# Altium schematic sheet EAP_DD_CO_Fixture.SchDoc01.SchDoc(EAP_DD_CO_Fixture.SchDoc01)
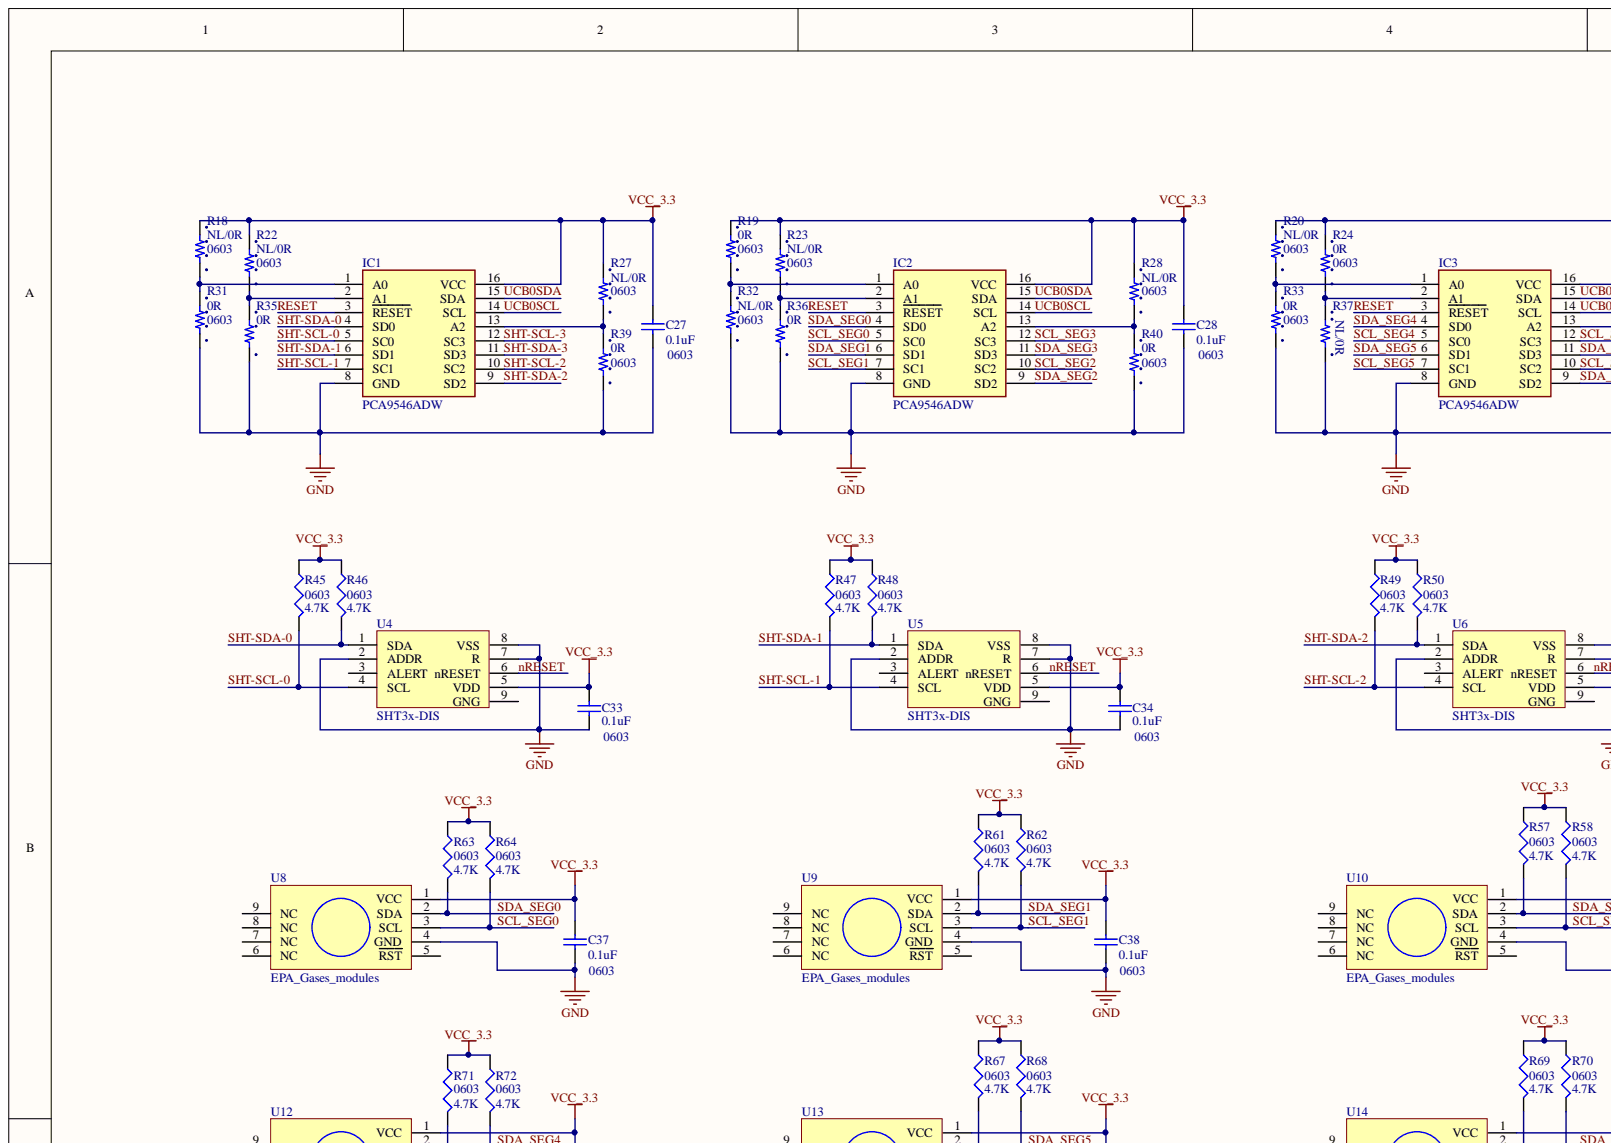
[diagram p0: EAP_DD_CO_Fixture.SchDoc01.SchDoc, top left]
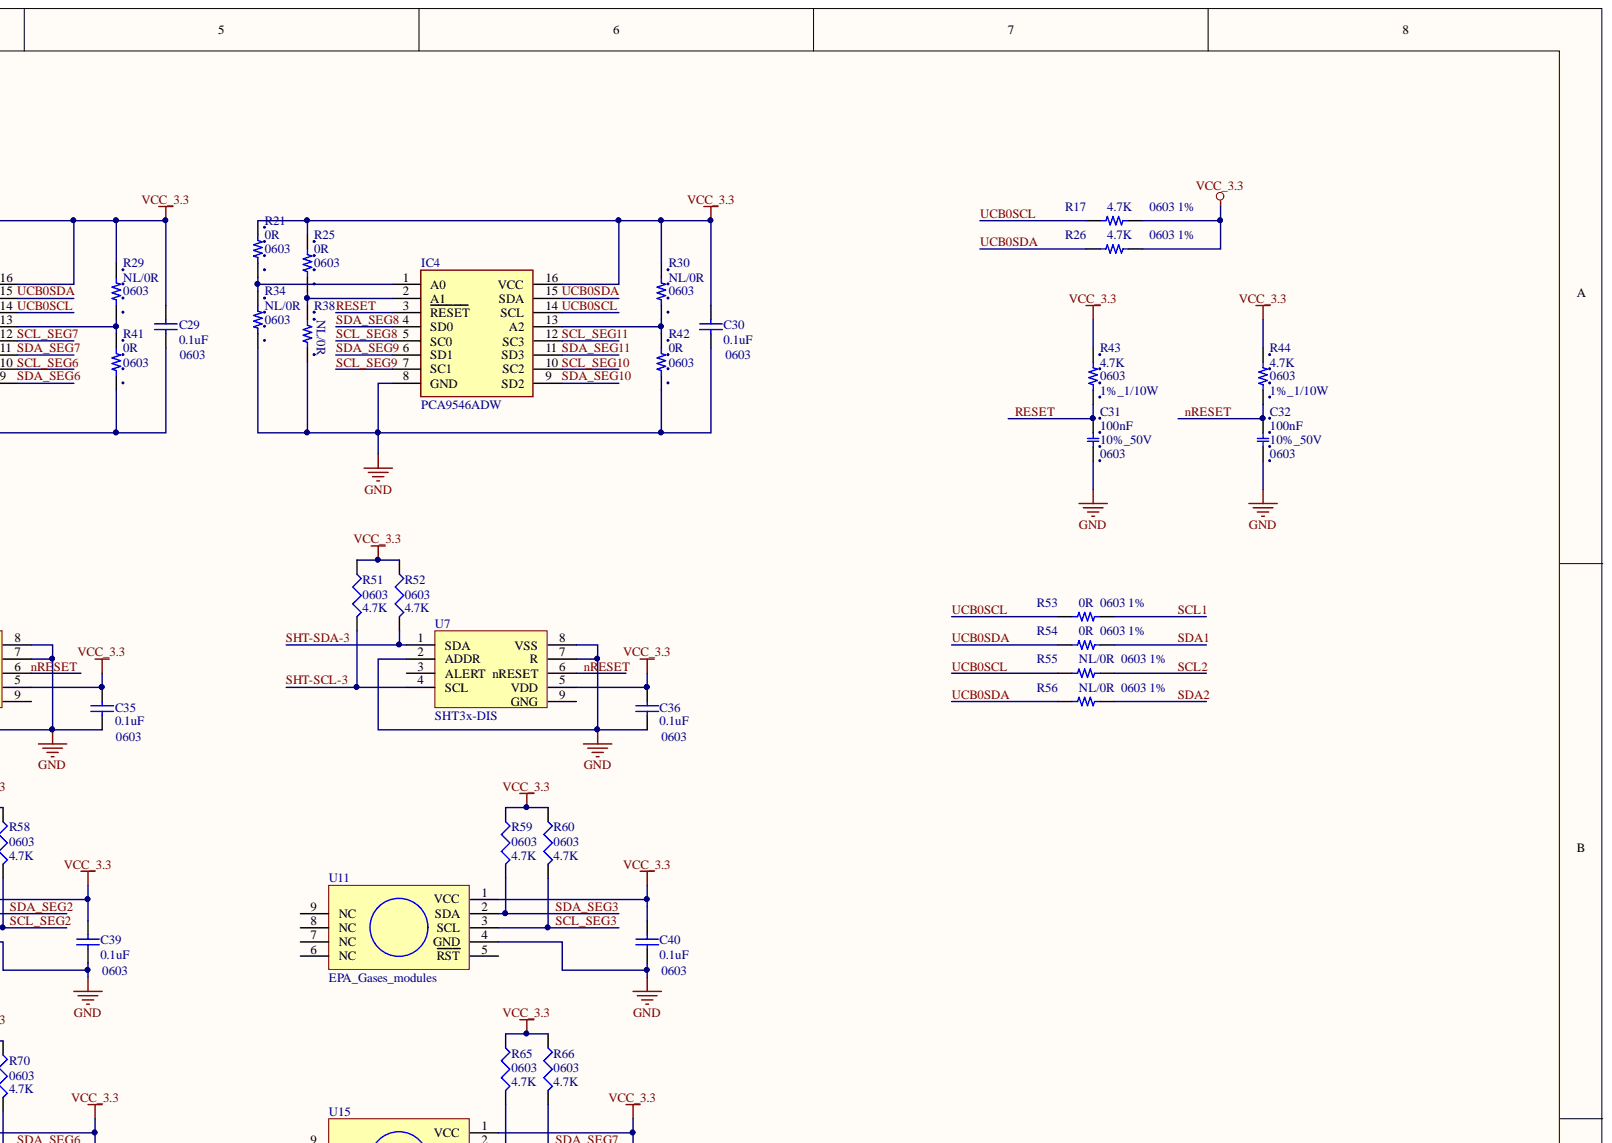
[diagram p1: EAP_DD_CO_Fixture.SchDoc01.SchDoc, top right]
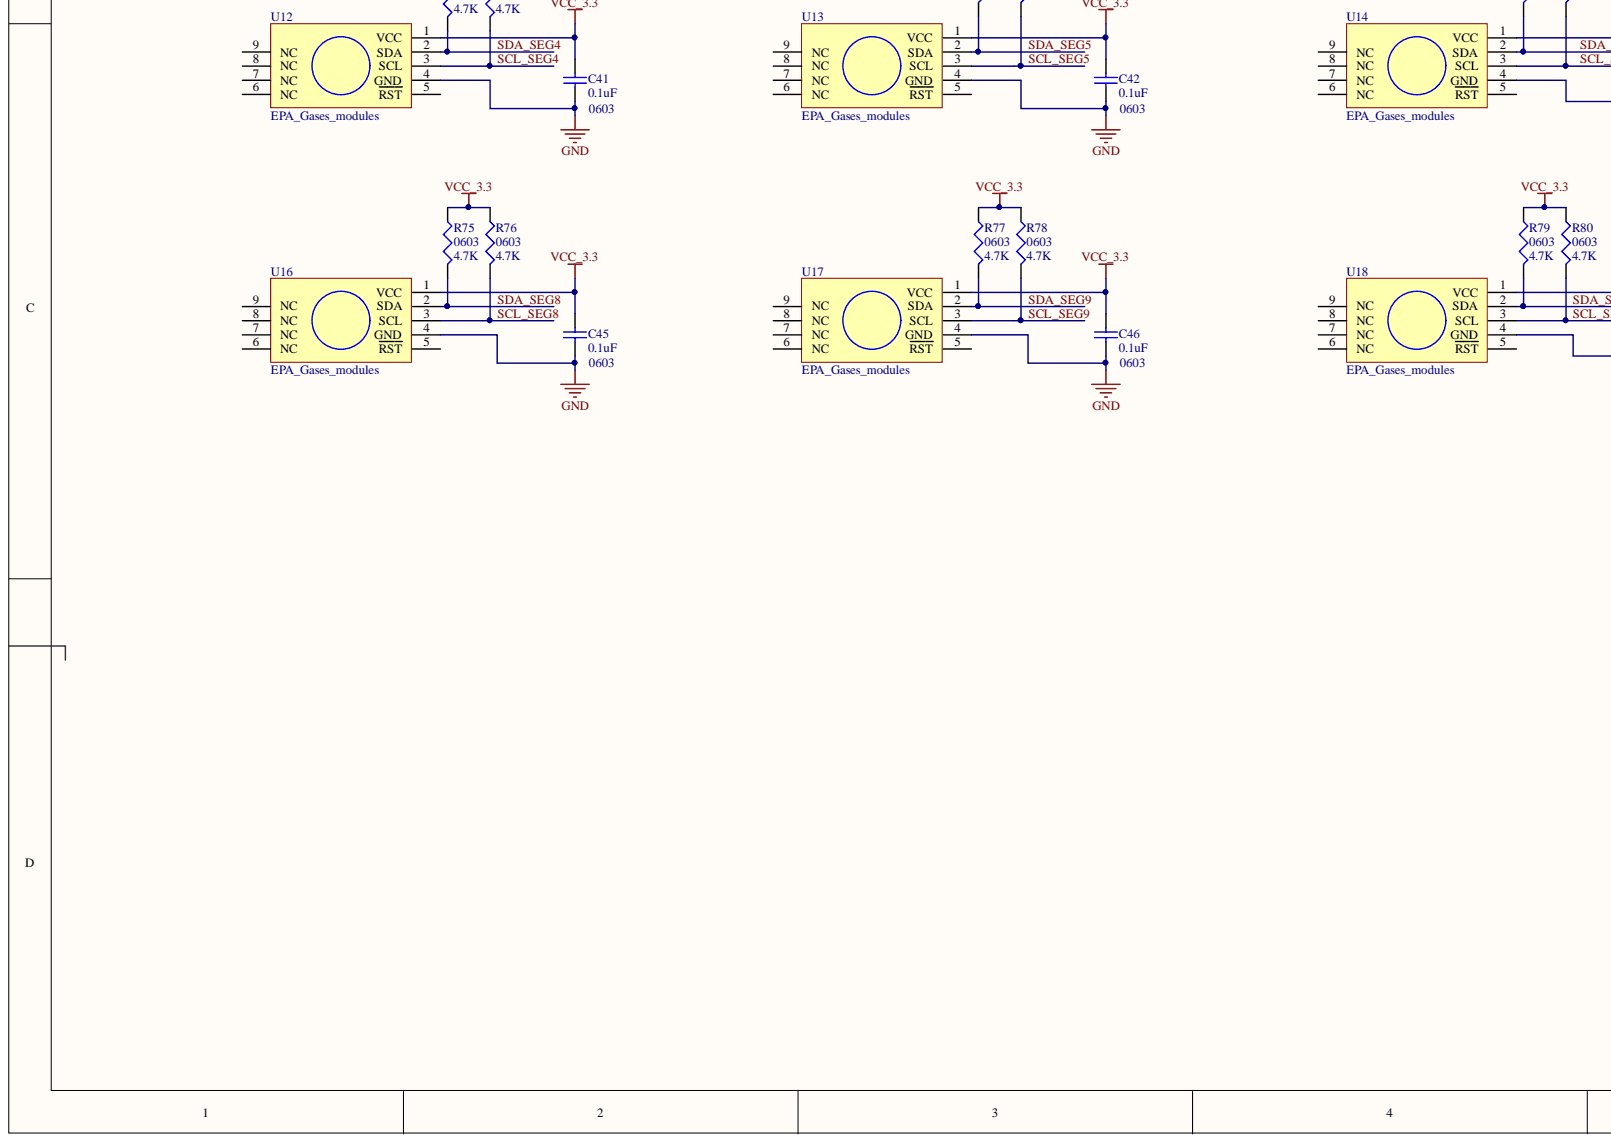
[diagram p2: EAP_DD_CO_Fixture.SchDoc01.SchDoc, bottom left]
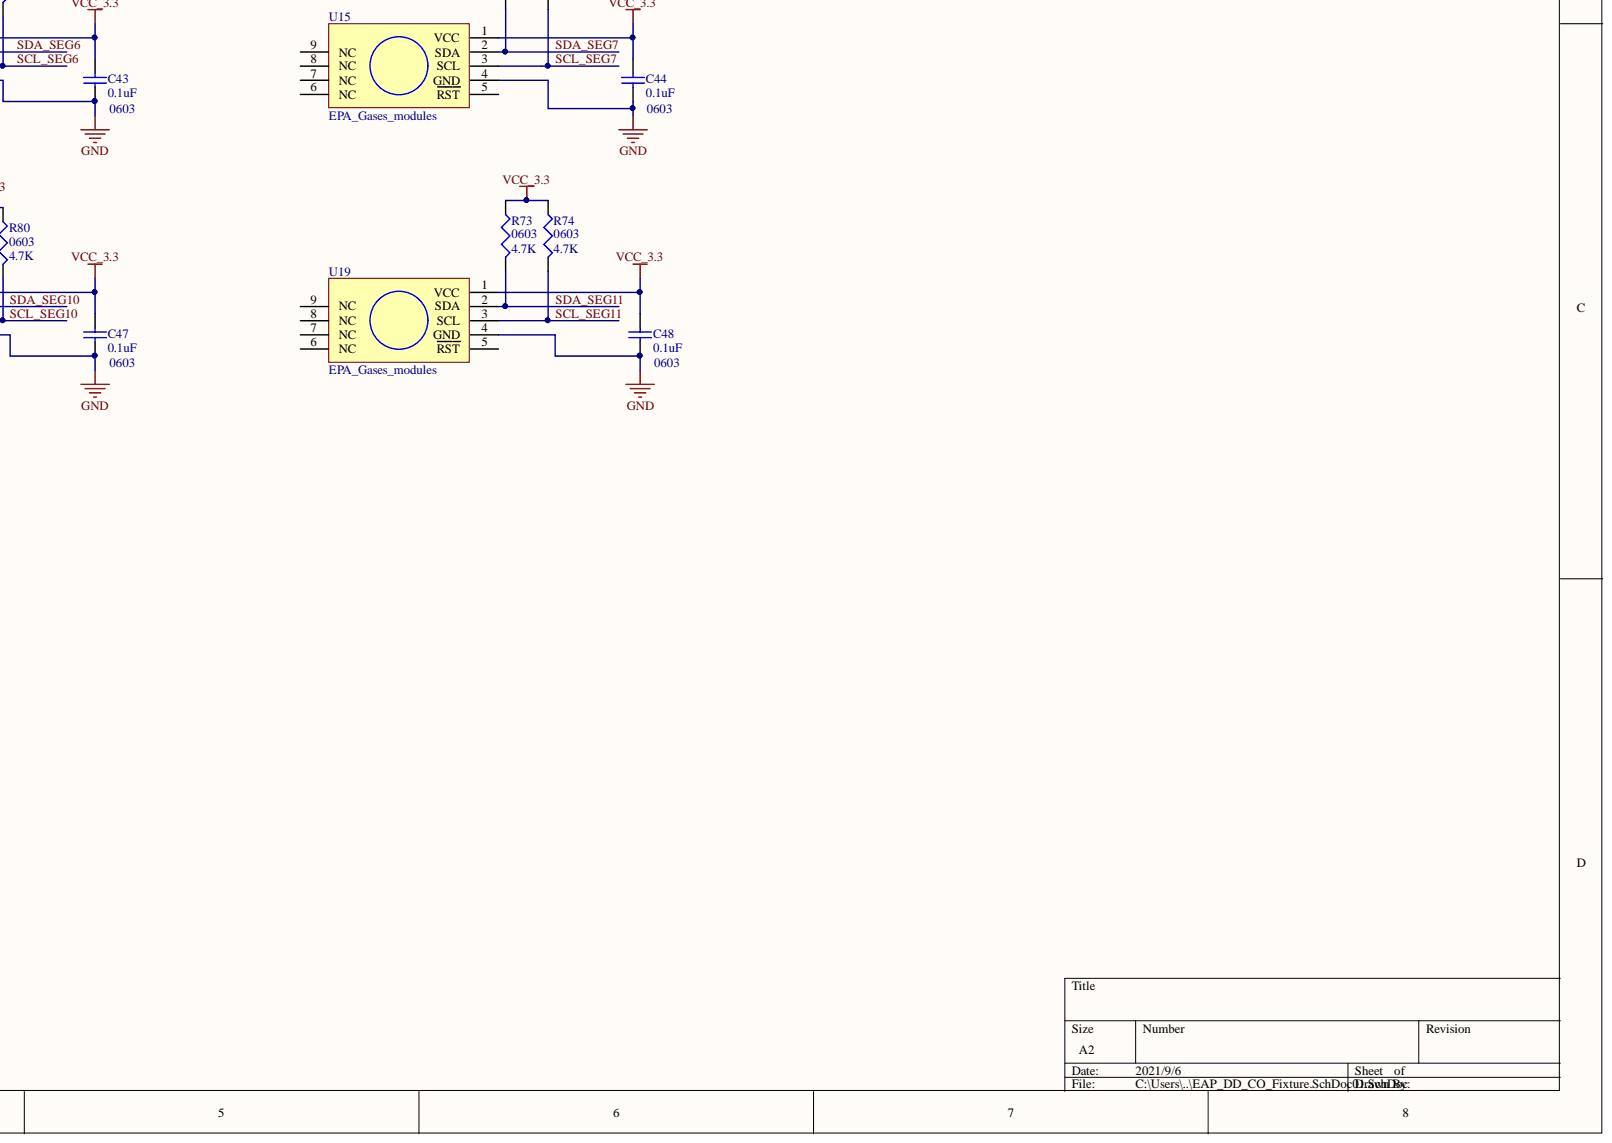
[diagram p3: EAP_DD_CO_Fixture.SchDoc01.SchDoc, bottom right]

## Parts
C27  0603  value 0.1uF  [CC0603]
C28  0603  value 0.1uF  [CC0603]
C29  0603  value 0.1uF  [CC0603]
C30  0603  value 0.1uF  [CC0603]
C31  100nF  Characteristic: 10%_50V  Package: 0603  [CC0603]
C32  100nF  Characteristic: 10%_50V  Package: 0603  [CC0603]
C33  0603  value 0.1uF  [CC0603]
C34  0603  value 0.1uF  [CC0603]
C35  0603  value 0.1uF  [CC0603]
C36  0603  value 0.1uF  [CC0603]
C37  0603  value 0.1uF  [CC0603]
C38  0603  value 0.1uF  [CC0603]
C39  0603  value 0.1uF  [CC0603]
C40  0603  value 0.1uF  [CC0603]
C41  0603  value 0.1uF  [CC0603]
C42  0603  value 0.1uF  [CC0603]
C43  0603  value 0.1uF  [CC0603]
C44  0603  value 0.1uF  [CC0603]
C45  0603  value 0.1uF  [CC0603]
C46  0603  value 0.1uF  [CC0603]
C47  0603  value 0.1uF  [CC0603]
C48  0603  value 0.1uF  [CC0603]
IC1  PCA9546ADW  [SOIC127P1030X265-16N]
IC2  PCA9546ADW  [SOIC127P1030X265-16N]
IC3  PCA9546ADW  [SOIC127P1030X265-16N]
IC4  PCA9546ADW  [SOIC127P1030X265-16N]
R17  4.7K  Characteristic: 1%  Package: 0603  [CR0603]
R18  NL/0R  Package: 0603  [CR0603]
R19  0R  Package: 0603  [CR0603]
R20  NL/0R  Package: 0603  [CR0603]
R21  0R  Package: 0603  [CR0603]
R22  NL/0R  Package: 0603  [CR0603]
R23  NL/0R  Package: 0603  [CR0603]
R24  0R  Package: 0603  [CR0603]
R25  0R  Package: 0603  [CR0603]
R26  4.7K  Characteristic: 1%  Package: 0603  [CR0603]
R27  NL/0R  Package: 0603  [CR0603]
R28  NL/0R  Package: 0603  [CR0603]
R29  NL/0R  Package: 0603  [CR0603]
R30  NL/0R  Package: 0603  [CR0603]
R31  0R  Package: 0603  [CR0603]
R32  NL/0R  Package: 0603  [CR0603]
R33  0R  Package: 0603  [CR0603]
R34  NL/0R  Package: 0603  [CR0603]
R35  0R  [CR0603]
R36  0R  [CR0603]
R37  NL/0R  [CR0603]
R38  NL/0R  [CR0603]
R39  0R  Package: 0603  [CR0603]
R40  0R  Package: 0603  [CR0603]
R41  0R  Package: 0603  [CR0603]
R42  0R  Package: 0603  [CR0603]
R43  4.7K  Characteristic: 1%_1/10W  Package: 0603  [CR0603]
R44  4.7K  Characteristic: 1%_1/10W  Package: 0603  [CR0603]
R45  0603  value 4.7K  [CR0603]
R46  0603  value 4.7K  [CR0603]
R47  0603  value 4.7K  [CR0603]
R48  0603  value 4.7K  [CR0603]
R49  0603  value 4.7K  [CR0603]
R50  0603  value 4.7K  [CR0603]
R51  0603  value 4.7K  [CR0603]
R52  0603  value 4.7K  [CR0603]
R53  0R  Characteristic: 1%  Package: 0603  [CR0603]
R54  0R  Characteristic: 1%  Package: 0603  [CR0603]
R55  NL/0R  Characteristic: 1%  Package: 0603  [CR0603]
R56  NL/0R  Characteristic: 1%  Package: 0603  [CR0603]
R57  0603  value 4.7K  [CR0603]
R58  0603  value 4.7K  [CR0603]
R59  0603  value 4.7K  [CR0603]
R60  0603  value 4.7K  [CR0603]
R61  0603  value 4.7K  [CR0603]
R62  0603  value 4.7K  [CR0603]
R63  0603  value 4.7K  [CR0603]
R64  0603  value 4.7K  [CR0603]
R65  0603  value 4.7K  [CR0603]
R66  0603  value 4.7K  [CR0603]
R67  0603  value 4.7K  [CR0603]
R68  0603  value 4.7K  [CR0603]
R69  0603  value 4.7K  [CR0603]
R70  0603  value 4.7K  [CR0603]
R71  0603  value 4.7K  [CR0603]
R72  0603  value 4.7K  [CR0603]
R73  0603  value 4.7K  [CR0603]
R74  0603  value 4.7K  [CR0603]
R75  0603  value 4.7K  [CR0603]
R76  0603  value 4.7K  [CR0603]
R77  0603  value 4.7K  [CR0603]
R78  0603  value 4.7K  [CR0603]
R79  0603  value 4.7K  [CR0603]
R80  0603  value 4.7K  [CR0603]
U4  SHT3x-DIS  [DFN-8-0.5MM]
U5  SHT3x-DIS  [DFN-8-0.5MM]
U6  SHT3x-DIS  [DFN-8-0.5MM]
U7  SHT3x-DIS  [DFN-8-0.5MM]
U8  EPA_Gases_modules  [AECL_EC_4P_V01]
U9  EPA_Gases_modules  [AECL_EC_4P_V01]
U10  EPA_Gases_modules  [AECL_EC_4P_V01]
U11  EPA_Gases_modules  [AECL_EC_4P_V01]
U12  EPA_Gases_modules  [AECL_EC_4P_V01]
U13  EPA_Gases_modules  [AECL_EC_4P_V01]
U14  EPA_Gases_modules  [AECL_EC_4P_V01]
U15  EPA_Gases_modules  [AECL_EC_4P_V01]
U16  EPA_Gases_modules  [AECL_EC_4P_V01]
U17  EPA_Gases_modules  [AECL_EC_4P_V01]
U18  EPA_Gases_modules  [AECL_EC_4P_V01]
U19  EPA_Gases_modules  [AECL_EC_4P_V01]

## Nets
GND: C27.2 C28.2 C29.2 C30.2 C31.2 C32.2 C33.2 C34.2 C35.2 C36.2 C37.2 C38.2 C39.2 C40.2 C41.2 C42.2 C43.2 C44.2 C45.2 C46.2 C47.2 C48.2 IC1.8(GND) IC2.8(GND) IC3.8(GND) IC4.8(GND) R31.2 R32.2 R33.2 R34.2 R35.2 R36.2 R37.2 R38.2 R39.2 R40.2 R41.2 R42.2 U4.2(ADDR) U4.7(R) U4.8(VSS) U5.2(ADDR) U5.7(R) U5.8(VSS) U6.2(ADDR) U6.7(R) U6.8(VSS) U7.2(ADDR) U7.7(R) U7.8(VSS) U8.4(GND) U9.4(GND) U10.4(GND) U11.4(GND) U12.4(GND) U13.4(GND) U14.4(GND) U15.4(GND) U16.4(GND) U17.4(GND) U18.4(GND) U19.4(GND)
VCC_3.3: C27.1 C28.1 C29.1 C30.1 C33.1 C34.1 C35.1 C36.1 C37.1 C38.1 C39.1 C40.1 C41.1 C42.1 C43.1 C44.1 C45.1 C46.1 C47.1 C48.1 IC1.16(VCC) IC2.16(VCC) IC3.16(VCC) IC4.16(VCC) R17.2 R18.1 R19.1 R20.1 R21.1 R22.1 R23.1 R24.1 R25.1 R26.2 R27.1 R28.1 R29.1 R30.1 R43.1 R44.1 R45.1 R46.1 R47.1 R48.1 R49.1 R50.1 R51.1 R52.1 R57.1 R58.1 R59.1 R60.1 R61.1 R62.1 R63.1 R64.1 R65.1 R66.1 R67.1 R68.1 R69.1 R70.1 R71.1 R72.1 R73.1 R74.1 R75.1 R76.1 R77.1 R78.1 R79.1 R80.1 U4.5(VDD) U5.5(VDD) U6.5(VDD) U7.5(VDD) U8.1(VCC) U9.1(VCC) U10.1(VCC) U11.1(VCC) U12.1(VCC) U13.1(VCC) U14.1(VCC) U15.1(VCC) U16.1(VCC) U17.1(VCC) U18.1(VCC) U19.1(VCC)
NRESET: C32.1 R44.2 U4.6(nRESET) U5.6(nRESET) U6.6(nRESET) U7.6(nRESET)
RESET: C31.1 IC1.3(RESET) IC2.3(RESET) IC3.3(RESET) IC4.3(RESET) R43.2
SCL1: R53.2
SCL2: R55.2
SCL_SEG0: IC2.5(SC0) R64.2 U8.3(SCL)
SCL_SEG1: IC2.7(SC1) R62.2 U9.3(SCL)
SCL_SEG2: IC2.10(SC2) R58.2 U10.3(SCL)
SCL_SEG3: IC2.12(SC3) R60.2 U11.3(SCL)
SCL_SEG4: IC3.5(SC0) R72.2 U12.3(SCL)
SCL_SEG5: IC3.7(SC1) R68.2 U13.3(SCL)
SCL_SEG6: IC3.10(SC2) R70.2 U14.3(SCL)
SCL_SEG7: IC3.12(SC3) R66.2 U15.3(SCL)
SCL_SEG8: IC4.5(SC0) R76.2 U16.3(SCL)
SCL_SEG9: IC4.7(SC1) R78.2 U17.3(SCL)
SCL_SEG10: IC4.10(SC2) R80.2 U18.3(SCL)
SCL_SEG11: IC4.12(SC3) R74.2 U19.3(SCL)
SDA1: R54.2
SDA2: R56.2
SDA_SEG0: IC2.4(SD0) R63.2 U8.2(SDA)
SDA_SEG1: IC2.6(SD1) R61.2 U9.2(SDA)
SDA_SEG2: IC2.9(SD2) R57.2 U10.2(SDA)
SDA_SEG3: IC2.11(SD3) R59.2 U11.2(SDA)
SDA_SEG4: IC3.4(SD0) R71.2 U12.2(SDA)
SDA_SEG5: IC3.6(SD1) R67.2 U13.2(SDA)
SDA_SEG6: IC3.9(SD2) R69.2 U14.2(SDA)
SDA_SEG7: IC3.11(SD3) R65.2 U15.2(SDA)
SDA_SEG8: IC4.4(SD0) R75.2 U16.2(SDA)
SDA_SEG9: IC4.6(SD1) R77.2 U17.2(SDA)
SDA_SEG10: IC4.9(SD2) R79.2 U18.2(SDA)
SDA_SEG11: IC4.11(SD3) R73.2 U19.2(SDA)
SHT-SCL-0: IC1.5(SC0) R45.2 U4.4(SCL)
SHT-SCL-1: IC1.7(SC1) R47.2 U5.4(SCL)
SHT-SCL-2: IC1.10(SC2) R49.2 U6.4(SCL)
SHT-SCL-3: IC1.12(SC3) R51.2 U7.4(SCL)
SHT-SDA-0: IC1.4(SD0) R46.2 U4.1(SDA)
SHT-SDA-1: IC1.6(SD1) R48.2 U5.1(SDA)
SHT-SDA-2: IC1.9(SD2) R50.2 U6.1(SDA)
SHT-SDA-3: IC1.11(SD3) R52.2 U7.1(SDA)
UCB0SCL: IC1.14(SCL) IC2.14(SCL) IC3.14(SCL) IC4.14(SCL) R17.1 R53.1 R55.1
UCB0SDA: IC1.15(SDA) IC2.15(SDA) IC3.15(SDA) IC4.15(SDA) R26.1 R54.1 R56.1
NetIC1_1: IC1.1(A0) R18.2 R31.1
NetIC1_2: IC1.2(A1) R22.2 R35.1
NetIC1_13: IC1.13(A2) R27.2 R39.1
NetIC2_1: IC2.1(A0) R19.2 R32.1
NetIC2_2: IC2.2(A1) R23.2 R36.1
NetIC2_13: IC2.13(A2) R28.2 R40.1
NetIC3_1: IC3.1(A0) R20.2 R33.1
NetIC3_2: IC3.2(A1) R24.2 R37.1
NetIC3_13: IC3.13(A2) R29.2 R41.1
NetIC4_1: IC4.1(A0) R21.2 R34.1
NetIC4_2: IC4.2(A1) R25.2 R38.1
NetIC4_13: IC4.13(A2) R30.2 R42.1
N00130:

Not connected: U4 3(ALERT) 9(GNG); U5 3(ALERT) 9(GNG); U6 3(ALERT) 9(GNG); U7 3(ALERT) 9(GNG); U8 5(RST) 6(NC) 7(NC) 8(NC) 9(NC); U9 5(RST) 6(NC) 7(NC) 8(NC) 9(NC); U10 5(RST) 6(NC) 7(NC) 8(NC) 9(NC); U11 5(RST) 6(NC) 7(NC) 8(NC) 9(NC); U12 5(RST) 6(NC) 7(NC) 8(NC) 9(NC); U13 5(RST) 6(NC) 7(NC) 8(NC) 9(NC); U14 5(RST) 6(NC) 7(NC) 8(NC) 9(NC); U15 5(RST) 6(NC) 7(NC) 8(NC) 9(NC); U16 5(RST) 6(NC) 7(NC) 8(NC) 9(NC); U17 5(RST) 6(NC) 7(NC) 8(NC) 9(NC); U18 5(RST) 6(NC) 7(NC) 8(NC) 9(NC); U19 5(RST) 6(NC) 7(NC) 8(NC) 9(NC)

## Library data of the parts
C27-C30 C33-C48 = Cap Semi: Capacitor (Semiconductor SIM Model); Component Kind: Standard; Published: 8-Jun-2000; Revision: July-2002: Re-released for DXP Platform.; Signal Integrity: Cap Semi; Simulation: CAP; Library Name: AECL_FAE.SCHLIB; Publisher: Altium Limited
C31 C32 = ChipCap: MLCC; AVL_Part: AVL; Manufacture: 華新科技股份有限公司(Walsin Technology Corporation)||台灣東電化股份有限公司(TDK Taiwan Corporation)||台灣太陽誘電(股)公司(Taiwan  Taiyo Yuden  Co., Ltd.); Manufacture_Part_Number: 0603X105K250CT||C1608X5R1E105KT||TMK107BJ105KA; Part_Number: 1100007912; CLASS: DISCRETE; ProcessType: SMT; Tolerance: 10%; Component Kind: Standard; Library Name: ES_passive.SCHLIB
IC1-IC4 = PCA9546ADW: Integrated Circuit; Manufacturer_Name: Texas Instruments; Arrow Part Number: PCA9546ADW; Arrow Price/Stock: https://www.arrow.com/en/products/pca9546adw/texas-instruments; Component Kind: Standard; Datasheet Link: http://www.ti.com/lit/gpn/PCA9546A; Height: 2.65mm; Manufacturer_Part_Number: PCA9546ADW; Mouser Part Number: 595-PCA9546ADW; Mouser Price/Stock: https://www.mouser.co.uk/ProductDetail/Texas-Instruments/PCA9546ADW?qs=wgAEGBTxy7kByJKC9EZsVQ%3D%3D; Library Name: EAP_DD_CO_Fixture.SCHLIB
R17 R26 R53-R56 = ChipRes: Chip Resistor; AVL_Part: AVL; Manufacture: 厚聲電子工業有限公司(Uniroyal Electronics Industry Co.,Ltd.)||國巨股份有限公司(Yageo Co.,Ltd.)||大毅科技股份有限公司(Ta-I Technolongy Co., Ltd.); Manufacture_Part_Number: 0603WAF2200T5E||RC0603FR-07220RL||RM06FTN2200; Part_Number: 105A522003; CLASS: DISCRETE; ProcessType: SMT; Component Kind: Standard; Library Name: ES_passive.SCHLIB; Tolerance: 1%
R18-R25 R27-R44 = ChipRes: Chip Resistor; AVL_Part: AVL; Manufacture: 厚聲電子工業有限公司(Uniroyal Electronics Industry Co.,Ltd.)||國巨股份有限公司(Yageo Co.,Ltd.)||大毅科技股份有限公司(Ta-I Technolongy Co., Ltd.); Manufacture_Part_Number: 0603WAF2200T5E||RC0603FR-07220RL||RM06FTN2200; Part_Number: 105A522003; CLASS: DISCRETE; ProcessType: SMT; Tolerance: 1%; Component Kind: Standard; Library Name: ES_passive.SCHLIB
R45-R52 R57-R80 = Res3: Resistor; Component Kind: Standard; Published: 8-Jun-2000; Revision: July-2002: Re-released for DXP Platform.; Signal Integrity: Res; Simulation: RESISTOR; Library Name: AECL_LoRa.SCHLIB; Publisher: Altium Limited
U4-U7 = SHT3x-DIS: Component Kind: Standard; Library Name: AECL_CO.SCHLIB
U8-U19 = EPA_Gases_modules: Component Kind: Standard; Description: EPA_Gases_modules; Library Name: EPA_Gases.SchLib
